# Altium schematic sheet CAN.SchDoc(CAN)
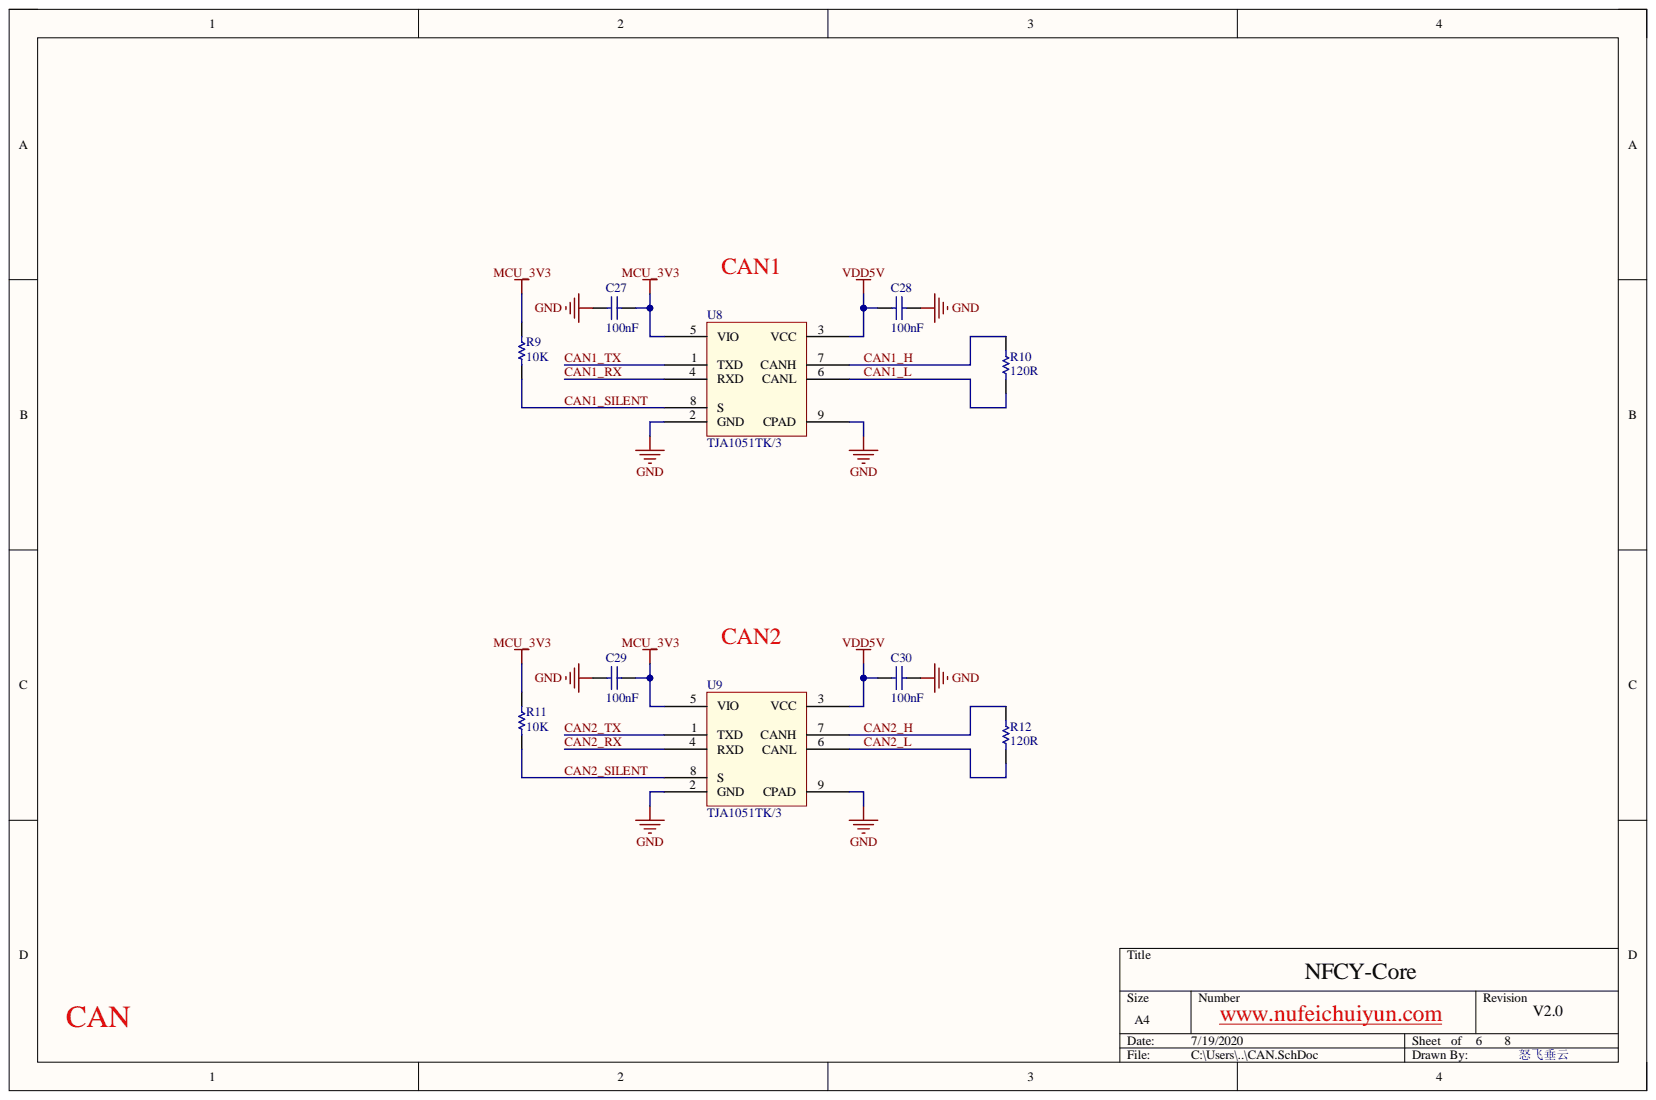
[diagram p0: CAN.SchDoc]

## Parts
C27  value 100nF  [CAP0402]  (hidden comment: CL05B104KO5NNNC)
C28  value 100nF  [CAP0402]  (hidden comment: CL05B104KO5NNNC)
C29  value 100nF  [CAP0402]  (hidden comment: CL05B104KO5NNNC)
C30  value 100nF  [CAP0402]  (hidden comment: CL05B104KO5NNNC)
R9  value 10K  [RES0402]  (hidden comment: RC0402FR-0710KL)
R10  value 120R  [RES0402]  (hidden comment: RC0402FR-07120RL)
R11  value 10K  [RES0402]  (hidden comment: RC0402FR-0710KL)
R12  value 120R  [RES0402]  (hidden comment: RC0402FR-07120RL)
U8  TJA1051TK/3  [HVSON8]
U9  TJA1051TK/3  [HVSON8]

## Nets
GND: C27.2 C28.1 C29.2 C30.1 U8.2(GND) U8.9(CPAD) U9.2(GND) U9.9(CPAD)
MCU_3V3: C27.1 C29.1 R9.2 R11.2 U8.5(VIO) U9.5(VIO)
VDD5V: C28.2 C30.2 U8.3(VCC) U9.3(VCC)
CAN1_H: R10.2 U8.7(CANH)
CAN1_L: R10.1 U8.6(CANL)
CAN1_RX: U8.4(RXD)
CAN1_SILENT: R9.1 U8.8(S)
CAN1_TX: U8.1(TXD)
CAN2_H: R12.2 U9.7(CANH)
CAN2_L: R12.1 U9.6(CANL)
CAN2_RX: U9.4(RXD)
CAN2_SILENT: R11.1 U9.8(S)
CAN2_TX: U9.1(TXD)

## Library data of the parts
C27-C30 = Cap: 贴片电容; manufacturer: SAMSUNG(三星); Component Kind: Standard; LatestRevisionDate: 17-Jul-2002; LatestRevisionNote: Re-released for DXP Platform.; Library Name: Miscellaneous Devices.IntLib; PackageDescription: Radial Cap, Thru-Hole; 2 Leads; 0.3 in Pin Spacing; PackageReference: RAD-0.3; Published: 8-Jun-2000; Signal Integrity: Cap; Simulation: CAP; Publisher: Altium Limited
R9-R12 = Res1: 贴片电阻; manufacturer: YAGEO(国巨); Component Kind: Standard; LatestRevisionDate: 17-Jul-2002; LatestRevisionNote: Re-released for DXP Platform.; Library Name: Miscellaneous Devices.IntLib; PackageDescription: Axial Device, Thru-Hole; 2 Leads; 0.3 in Pin Spacing; PackageReference: AXIAL-0.3; Published: 8-Jun-2000; Signal Integrity: Res; Simulation: RESISTOR; Publisher: Altium Limited
U8 U9 = TJA1051TK/3: CAN收发器; manufacturer: NXP; Component Kind: Standard; Library Name: Chip.SchLib
